# Altium schematic sheet External Connectors.SchDoc("External Connectors")
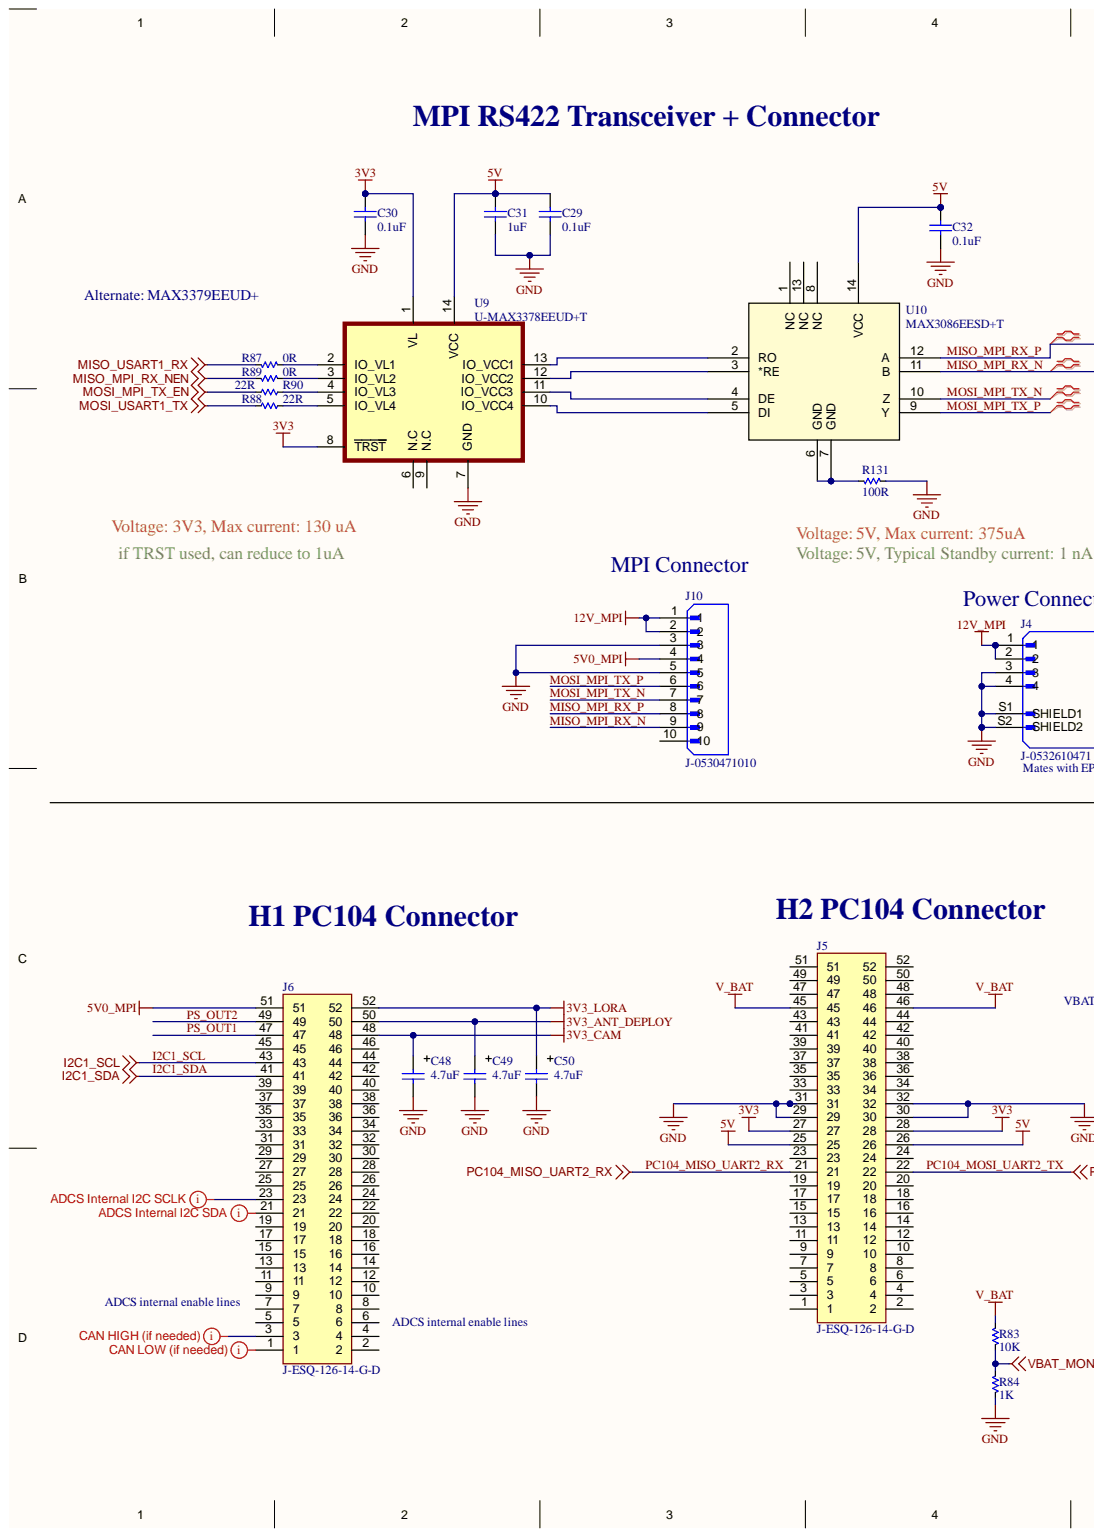
[diagram p0: External Connectors.SchDoc, left]
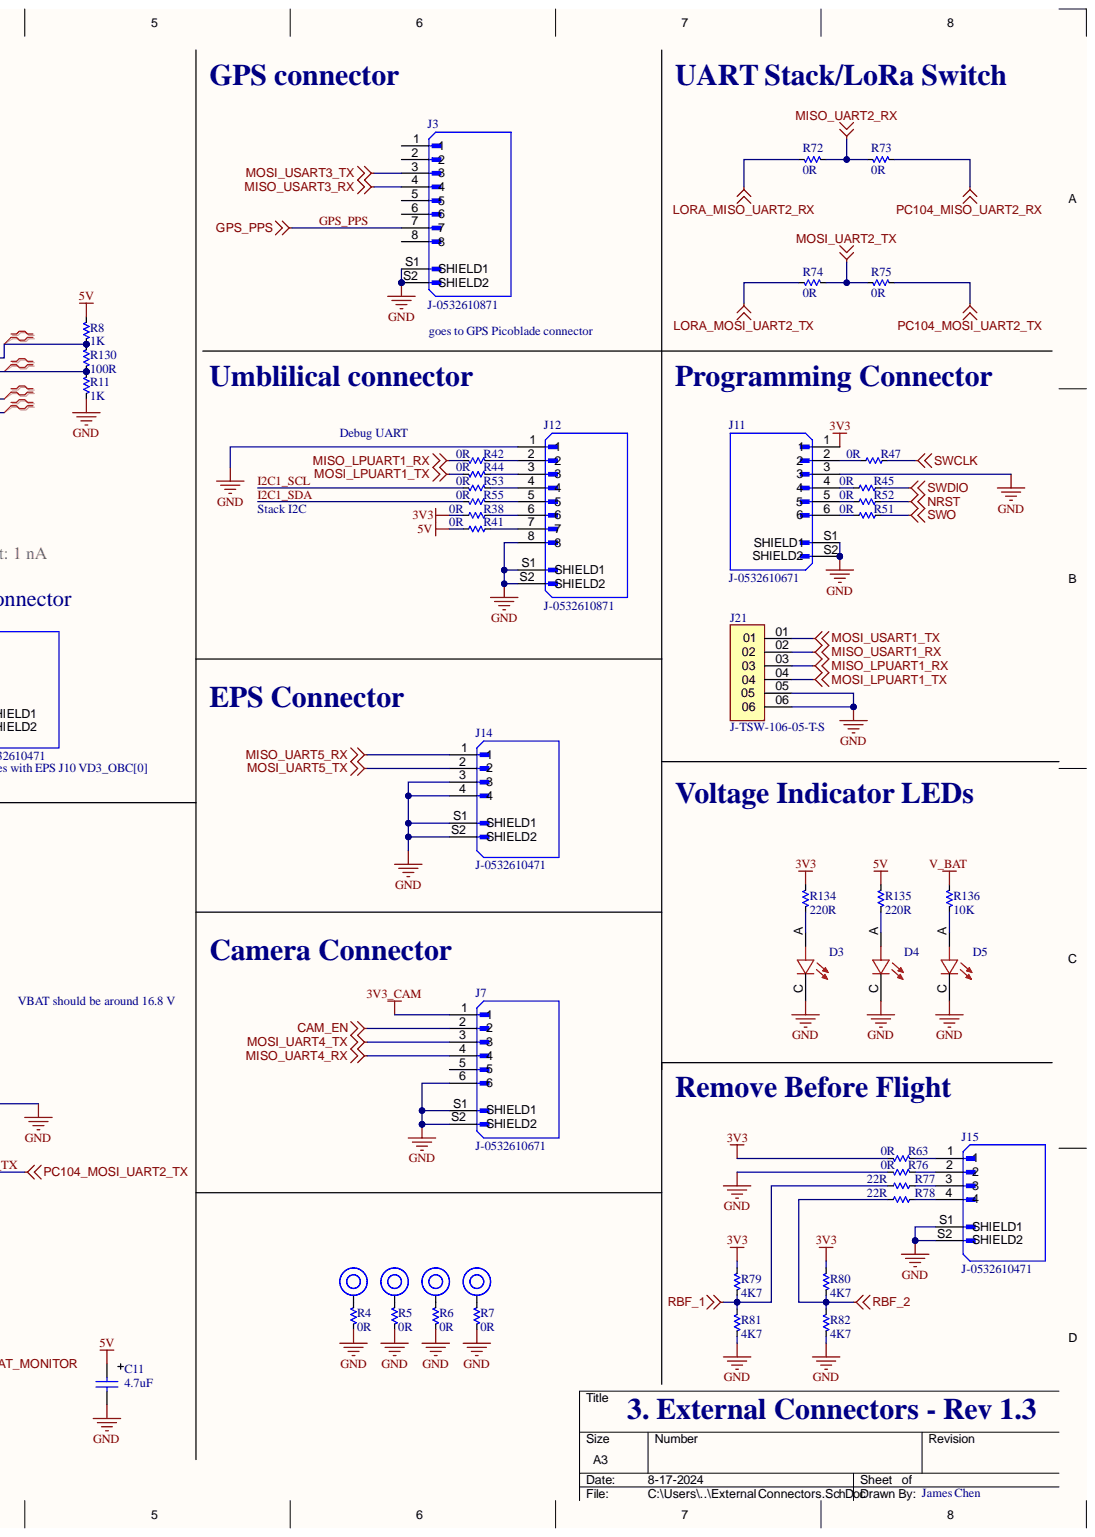
[diagram p1: External Connectors.SchDoc, right]

## Parts
*1  PC104 Mounting  [PC104 Mounting Points]  (value not drawn)  (no BOM)
*2  PC104 Mounting  [PC104 Mounting Points]  (value not drawn)  (no BOM)
*3  PC104 Mounting  [PC104 Mounting Points]  (value not drawn)  (no BOM)
*4  PC104 Mounting  [PC104 Mounting Points]  (value not drawn)  (no BOM)
C11  4.7uF  [CAP TANT 1206 (A) TCJ]
C29  0.1uF  [0603]
C30  0.1uF  [0603]
C31  1uF  [0603]
C32  0.1uF  [0603]
C48  4.7uF  [CAP TANT 1206 (A) TCJ]
C49  4.7uF  [CAP TANT 1206 (A) TCJ]
C50  4.7uF  [CAP TANT 1206 (A) TCJ]
D3  D-SML-D12U1WT86  [D-SML-D12U1WT86]  (value not drawn)
D4  D-SML-D12U1WT86  [D-SML-D12U1WT86]  (value not drawn)
D5  D-SML-D12U1WT86  [D-SML-D12U1WT86]  (value not drawn)
J3  J-0532610871  [J-532610871]
J4  J-0532610471  [J-53261-0471]
J5  J-ESQ-126-14-G-D  [J-ESQ-126-14-G-D]
J6  J-ESQ-126-14-G-D  [J-ESQ-126-14-G-D]
J7  J-0532610671  [J-0532610671]
J10  J-0530471010  [J-0530471010]
J11  J-0532610671  [J-0532610671]
J12  J-0532610871  [J-532610871]
J14  J-0532610471  [J-53261-0471]
J15  J-0532610471  [J-53261-0471]
J21  J-TSW-106-05-T-S  [J-TSW-106-05-T-S]
R4  0R  [0603]
R5  0R  [0603]
R6  0R  [0603]
R7  0R  [0603]
R8  1K  [0603]
R11  1K  [0603]
R38  0R  [0603]
R41  0R  [0603]
R42  0R  [0603]
R44  0R  [0603]
R45  0R  [0603]
R47  0R  [0603]
R51  0R  [0603]
R52  0R  [0603]
R53  0R  [0603]
R55  0R  [0603]
R63  0R  [0603]
R72  0R  [0603]
R73  0R  [0603]
R74  0R  [0603]
R75  0R  [0603]
R76  0R  [0603]
R77  22R  [0603]
R78  22R  [0603]
R79  4K7  [0603]
R80  4K7  [0603]
R81  4K7  [0603]
R82  4K7  [0603]
R83  10K  [0603]
R84  1K  [0603]
R87  0R  [0603]
R88  22R  [0603]
R89  0R  [0603]
R90  22R  [0603]
R130  100R  [0603]
R131  100R  [0603]
R134  220R  [0603]
R135  220R  [0603]
R136  10K  [0603]
U9  U-MAX3378EEUD+T  [U-MAX3378EEUD+T]
U10  MAX3086EESD+T  [U-MAX3086EESD+T]

## Nets
3V3: C30.1 J5.27 J5.28 J11.1 R38.2 R63.1 R79.2 R80.2 R134.2 U9.1(VL) U9.8(TRST)
3V3_ANT_DEPLOY: C49.1 J6.50
3V3_CAM: C48.1 J6.48 J7.1
3V3_LORA: C50.1 J6.52
5V: C11.1 C29.1 C31.2 C32.1 J5.25 J5.26 J6.51 J10.4 R8.2 R41.2 R135.2 U9.14(VCC) U10.14(VCC)
12V_MPI: J4.1 J4.2 J10.1 J10.2
CAM_EN: J7.2
GND: C11.2 C29.2 C30.2 C31.1 C32.2 C48.2 C49.2 C50.2 D3.C D4.C D5.C J3.S1(SHIELD1) J3.S2(SHIELD2) J4.3 J4.4 J4.S1(SHIELD1) J4.S2(SHIELD2) J5.29 J5.30 J5.31 J5.32 J7.6 J7.S1(SHIELD1) J7.S2(SHIELD2) J10.3 J10.5 J11.3 J11.S1(SHIELD1) J11.S2(SHIELD2) J12.1 J12.8 J12.S1(SHIELD1) J12.S2(SHIELD2) J14.3 J14.4 J14.S1(SHIELD1) J14.S2(SHIELD2) J15.S1(SHIELD1) J15.S2(SHIELD2) J21.05 J21.06 R4.1 R5.1 R6.1 R7.1 R11.1 R76.1 R81.1 R82.1 R84.1 R131.1 U9.7(GND)
LORA_MISO_UART2_RX: R72.1
LORA_MOSI_UART2_TX: R74.1
MISO_LPUART1_RX: J21.03 R42.1
MISO_MPI_RX_NEN: R89.1
MISO_UART2_RX: R72.2 R73.1
MISO_UART4_RX: J7.4
MISO_UART5_RX: J14.1
MISO_USART1_RX: J21.02 R87.1
MISO_USART3_RX: J3.4
MOSI_LPUART1_TX: J21.04 R44.1
MOSI_MPI_TX_EN: R90.1
MOSI_UART2_TX: R74.2 R75.1
MOSI_UART4_TX: J7.3
MOSI_UART5_TX: J14.2
MOSI_USART1_TX: J21.01 R88.2
MOSI_USART3_TX: J3.3
NRST: R52.1
RBF_1: R77.1 R79.1 R81.2
RBF_2: R78.1 R80.1 R82.2
SWCLK: R47.1
SWDIO: R45.1
SWO: R51.1
V_BAT: J5.45 J5.46 R83.2 R136.2
VBAT_MONITOR: R83.1 R84.2
GPS_PPS: J3.7
I2C1_SCL: J6.43 R53.1
I2C1_SDA: J6.41 R55.1
MISO_MPI_RX_N: J10.9 R11.2 R130.1 U10.11(B)
MISO_MPI_RX_P: J10.8 R8.1 R130.2 U10.12(A)
MOSI_MPI_TX_N: J10.7 U10.10(Z)
MOSI_MPI_TX_P: J10.6 U10.9(Y)
PC104_MISO_UART2_RX: J5.21 R73.2
PC104_MOSI_UART2_TX: J5.22 R75.2
PS_OUT1: J6.47
PS_OUT2: J6.49
Net*1_1: *1.1 R4.2
Net*2_1: *2.1 R5.2
Net*3_1: *3.1 R6.2
Net*4_1: *4.1 R7.2
NetD3_A: D3.A R134.1
NetD4_A: D4.A R135.1
NetD5_A: D5.A R136.1
NetJ11_2: J11.2 R47.2
NetJ11_4: J11.4 R45.2
NetJ11_5: J11.5 R52.2
NetJ11_6: J11.6 R51.2
NetJ12_2: J12.2 R42.2
NetJ12_3: J12.3 R44.2
NetJ12_4: J12.4 R53.2
NetJ12_5: J12.5 R55.2
NetJ12_6: J12.6 R38.1
NetJ12_7: J12.7 R41.1
NetJ15_1: J15.1 R63.2
NetJ15_2: J15.2 R76.2
NetJ15_3: J15.3 R77.2
NetJ15_4: J15.4 R78.2
NetR87_2: R87.2 U9.2(IO_VL1)
NetR88_1: R88.1 U9.5(IO_VL4)
NetR89_2: R89.2 U9.3(IO_VL2)
NetR90_2: R90.2 U9.4(IO_VL3)
NetR131_2: R131.2 U10.6(GND) U10.7(GND)
NetU9_10: U9.10(IO_VCC4) U10.5(DI)
NetU9_11: U9.11(IO_VCC3) U10.4(DE)
NetU9_12: U9.12(IO_VCC2) U10.3(*RE)
NetU9_13: U9.13(IO_VCC1) U10.2(RO)

Not connected: J3 1 2 5 6 8; J5 1 2 3 4 5 6 7 8 9 10 11 12 13 14 15 16 17 18 19 20 23 24 33 34 35 36 37 38 39 40 41 42 43 44 47 48 49 50 51 52; J6 1 2 3 4 5 6 7 8 9 10 11 12 13 14 15 16 17 18 19 20 21 22 23 24 25 26 27 28 29 30 31 32 33 34 35 36 37 38 39 40 42 44 45 46; J7 5; J10 10; U9 6(N.C) 9(N.C); U10 1(NC) 8(NC) 13(NC)

## Library data of the parts
C11 C48-C50 = CT-474-M-20V-OTH-1206: 4.7 µF Molded Tantalum Capacitors 20 V 1206 (3216 Metric) 4Ohm; Manufacturer: AVX Corporation; Manufacturer Part #: TAJA475K020RNJ; Component Kind: Standard; ComponentLink1Description: Datasheet; ComponentLink2Description: Manufacturer Website; Distributor: Digikey; Distributor Part #: 478-1668-1-ND; Part Description: 4.7 µF Molded Tantalum Capacitors 20 V 1206 (3216 Metric) 4Ohm; Value: 4.7uF; Library Name: OBC Development Board.SchLib
C29 C30 C32 = CC-100nF-25V-X7R-0603: 25V 100nF X7R ±10% 0603 Multilayer Ceramic Capacitors MLCC - SMD/SMT ROHS; Manufacturer: Murata Electronics; Manufacturer Part #: GCJ188R71E104KA12D; Max Exposed Voltage: 6; Rated Voltage: 25; Component Kind: Standard; ComponentLink1description: Datasheet; ComponentLink1URL: https://ds.yuden.co.jp/TYCOMPAS/ut/detail?pn=TMK105BJ104KV-F%20%20&u=M; ComponentLink2description: Manufacturer Website; ComponentLink2URL: https://www.yuden.co.jp/or/; Distributor: LCSC; Distributor Part #: C354391; Highest Rated Operating Temperature: 125; Lowest Rated Operating Temperature: -55; Part Description: 25V 100nF X7R ±10% 0603 Multilayer Ceramic Capacitors MLCC - SMD/SMT ROHS; Value: 0.1uF; Library Name: OBC Development Board.SchLib
C31 = CC-105-K-25V-X7R-0603: CAP CER 1UF 25V X7R 0603; Manufacturer: Yageo; Manufacturer Part #: CC0603KRX7R8BB105; Component Kind: Standard; ComponentLink1Description: Datasheet; ComponentLink1URL: https://www.yageo.com/upload/media/product/productsearch/datasheet/mlcc/UPY-GPHC_X7R_6.3V-to-50V_18.pdf; ComponentLink2Description: Manufacture Website; ComponentLink2URL: https://www.yageo.com/en/Home; Distributor: Digikey; Distributor Part #: 311-1802-1-ND; Part Description: CAP CER 1UF 25V X7R 0603; SignalCraft Part #: CC-105-K-25V-X7R-0603; Value: 1uF; Library Name: OBC Development Board.SchLib
D3-D5 = D-SML-D12U1WT86: Red 620nm LED Indication - Discrete 2.2V 0603 _1608 Metric; Manufacturer: Rohm Semiconductor; Component Kind: Standard; Distributor: Digikey; Distributor Part #: SML-D12U1WT86CT-ND; Manufacturer Part #: SML-D12U1WT86; Library Name: OBC Development Board.SchLib
J3 J12 = J-0532610871: CONN HEADER SMD R/A 8POS 1.25MM; Manfacturer: Molex; Component Kind: Standard; Distributor: Digi-Key; Distributor Part #: WM7626CT-ND; Manfacturer Part #: 0532610871; Library Name: OBC Development Board.SchLib
J4 J14 J15 = J-0532610471: CONN HEADER SMD R/A 4POS 1.25MM; Manfacturer: Molex; Component Kind: Standard; Distributor: Digi-Key; Distributor Part #: WM7622CT-ND; Manfacturer Part #: 0532610471; Library Name: OBC Development Board.SchLib
J5 J6 = J-ESQ-126-14-G-D: CONN SOCKET 52POS 0.1 GOLD PCB; Manufacturer: Samtec Inc.; Component Kind: Standard; Distributor: Digikey; Distributor Part #: SAM11114-ND; Manufacturer Part #: ESQ-126-14-G-D; Library Name: OBC Development Board.SchLib
J7 J11 = J-0532610671: CONN HEADER SMD R/A 6POS 1.25MM; Manfacturer: Molex; Component Kind: Standard; Distributor: Digi-Key; Distributor Part #: WM7624CT-ND; Manfacturer Part #: 0532610671; Library Name: OBC Development Board.SchLib
J10 = J-0530471010: CONN HEADER VERT 10POS 1.25MM; Manufacturer: Molex; Component Kind: Standard; Distributor: Digikey; Distributor Part #: WM1739-ND; Manufacturer Part #: 0530471010; Library Name: OBC Development Board.SchLib
J21 = J-TSW-106-05-T-S: CONN HEADER VERT 6POS 2.54MM; Distrbutor part #: SAM12323-ND; Manufacturer: Samtec; Distrbutor: None; Component Kind: Standard; Manufacturer Part #: TSW-106-05-T-S; Price: None; Library Name: OBC Development Board.SchLib
R4-R7 R38 R41 R42 R44 R45 R47 R51-R53 R55 R63 R72-R76 R87 R89 = RT-0R00-F-1/10W-0603: 0 Ohms Jumper 0.1W, 1/10W Chip Resistor 0603 (1608 Metric) Automotive AEC-Q200 Thick Film; Manufacturer: Stackpole Electronics Inc; Manufacturer Part #: RMCF0603ZT0R00; Component Kind: Standard; ComponentLink1description: Datasheet; ComponentLink1URL: https://industrial.panasonic.com/ww/products/resistors/chip-resistors/general-purpose-chip-resistors/models/ERJ2GE0R00X; ComponentLink2description: Manufacturer Website; ComponentLink2URL: https://industrial.panasonic.com/ww/products/resistors/chip-resistors/general-purpose-chip-resistors/models/ERJ2GE0R00X; Distributor: Digikey; Distributor Part #: RMCF0603ZT0R00CT-ND; Part Description: 0 Ohms Jumper 0.1W, 1/10W Chip Resistor 0603 (1608 Metric) Automotive AEC-Q200 Thick Film; Value: 0R; Library Name: OBC Development Board.SchLib
R8 R11 R84 = RT-1K0-F-1/16W-0603: RES SMD 1K OHM 1% 1/16W 0603; Manufacturer: TE Connectivity Passive Product; Manufacturer Part #: CPF0603F1K0C1; Component Kind: Standard; ComponentLink1description: Datasheet; ComponentLink1URL: https://www.vishay.com/docs/20035/dcrcwe3.pdf; ComponentLink2description: Manufacturer Website; ComponentLink2URL: https://www.vishay.com/; Distributor: Digikey; Distributor Part #: A102226CT-ND; Part Description: RES SMD 1K OHM 1% 1/16W 0603; Value: 1K; Library Name: OBC Development Board.SchLib
R77 R78 R88 R90 = RT-22R0-F-1/16W-0603: 22 Ohms ±0.5% 0.063W, 1/16W Chip Resistor 0603 (1608 Metric) - Thin Film; Manufacturer: Susumu; Manufacturer Part #: RR0816Q-220-D; Component Kind: Standard; ComponentLink1description: Datasheet; ComponentLink1URL: https://www.vishay.com/docs/20035/dcrcwe3.pdf; ComponentLink2description: Manufacturer Website; ComponentLink2URL: https://www.vishay.com/; Distributor: Digikey; Distributor Part #: RR08Q22DCT-ND; Part Description: 22 Ohms ±0.5% 0.063W, 1/16W Chip Resistor 0603 (1608 Metric) - Thin Film; Value: 22R; Library Name: OBC Development Board.SchLib
R79-R82 = RT-4K7-F-1/16W-0603: RES SMD 4.7K OHM 1% 1/10W 0603; Manufacturer: Bourns Inc.; Manufacturer Part #: CR0603-FX-4701ELF; Component Kind: Standard; ComponentLink1description: Datasheet; ComponentLink1URL: https://www.vishay.com/docs/20035/dcrcwe3.pdf; ComponentLink2description: Manufacturer Website; ComponentLink2URL: https://www.vishay.com/; Distributor: Digikey; Distributor Part #: CR0603-FX-4701ELFCT-ND; Part Description: RES SMD 4.7K OHM 1% 1/10W 0603; Value: 4K7; Library Name: OBC Development Board.SchLib
R83 = RT-10K0-F-1/16W-0603: RES 10K OHM 1% 1/8W 0603; Manufacturer: Stackpole Electronics Inc; Manufacturer Part #: RNCP0603FTD10K0; Component Kind: Standard; ComponentLink1description: Datasheet; ComponentLink1URL: https://www.vishay.com/docs/20035/dcrcwe3.pdf; ComponentLink2description: Manufacturer Website; ComponentLink2URL: https://www.vishay.com/; Distributor: Digikey; Distributor Part #: RNCP0603FTD10K0CT-ND; Part Description: RES 10K OHM 1% 1/8W 0603; Value: 10K; Library Name: Burn Wire Dev Board.SchLib
R130 R131 = RT-100R-F-1/16W-0603: RES SMD 100 OHM 0.5% 1/16W 0603; Manufacturer: Susumu; Manufacturer Part #: RR08P100DCT-ND; Component Kind: Standard; Distributor: Digikey; Distributor Part #: RR08P100DCT-ND; Part Description: RES SMD 100 OHM 0.5% 1/16W 0603; Value: 100R; Library Name: OBC Development Board.SchLib
R134 R135 = RT-220R-F-1/16W-0603: 220 Ohms ±0.5% 0.063W, 1/16W Chip Resistor 0603 (1608 Metric) - Thin Film; Manufacturer: Susumu; Manufacturer Part #: RR0816P-221-D; Component Kind: Standard; Distributor: Digikey; Distributor Part #: RR08P220DCT-ND; Part Description: 220 Ohms ±0.5% 0.063W, 1/16W Chip Resistor 0603 (1608 Metric) - Thin Film; Value: 220R; Library Name: OBC Development Board.SchLib
R136 = RT-10K0-F-1/16W-0603: 10 kOhms ±0.1% 0.063W, 1/16W Chip Resistor 0603 (1608 Metric) - Thin Film; Manufacturer: TE Connectivity Passive Product; Manufacturer Part #: CPF0603B10KE; Component Kind: Standard; ComponentLink1description: Datasheet; ComponentLink1URL: https://www.vishay.com/docs/20035/dcrcwe3.pdf; ComponentLink2description: Manufacturer Website; ComponentLink2URL: https://www.vishay.com/; Distributor: Digikey; Distributor Part #: A119886CT-ND; Part Description: 10 kOhms ±0.1% 0.063W, 1/16W Chip Resistor 0603 (1608 Metric) - Thin Film; Value: 10K; Library Name: OBC Development Board.SchLib
U9 = U-MAX3378EEUD+T: IC TRNSLTR BIDIRECTIONAL 14TSSOP; Manufacturer: Maxim Integrated; Component Kind: Standard; Distributor: Digikey; Distributor Part #: MAX3378EEUD+TCT-ND; LCSC Part #: C143441; Manufacturer Part #: MAX3378EEUD+T; Library Name: OBC Development Board.SchLib
U10 = U-MAX3086EESD+T: IC TRANSCEIVER FULL 1/1 14SOIC; Manufacturer: Maxim Integrated Products; Component Kind: Standard; Distributor: Digikey; Distributor Part #: MAX3086EESD+TCT-ND; LCSC Part #: C2671104; Manufacturer Part #: MAX3086EESD+T; Type: DEV; Library Name: OBC Development Board.SchLib
*1-*4 = PC104 Mounting: Library Name: OBC Development Board.SchLib
